# Altium schematic sheet cpu.SchDoc(cpu)
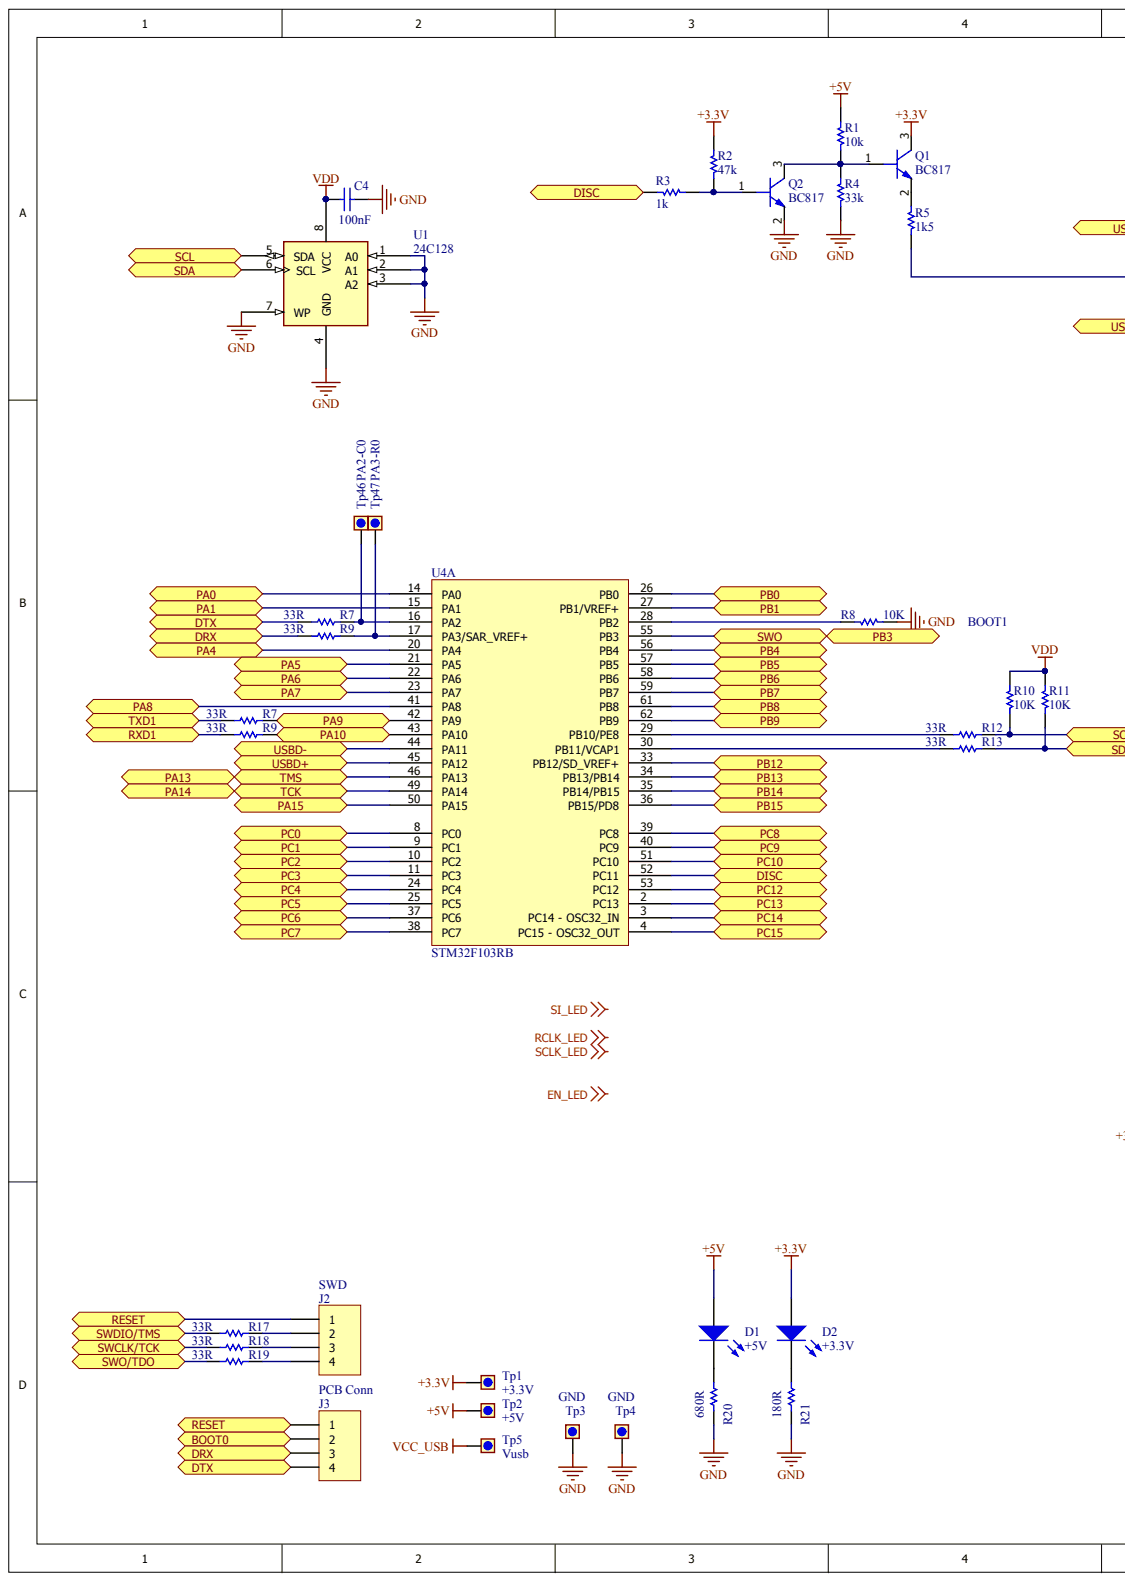
[diagram p0: cpu.SchDoc, left]
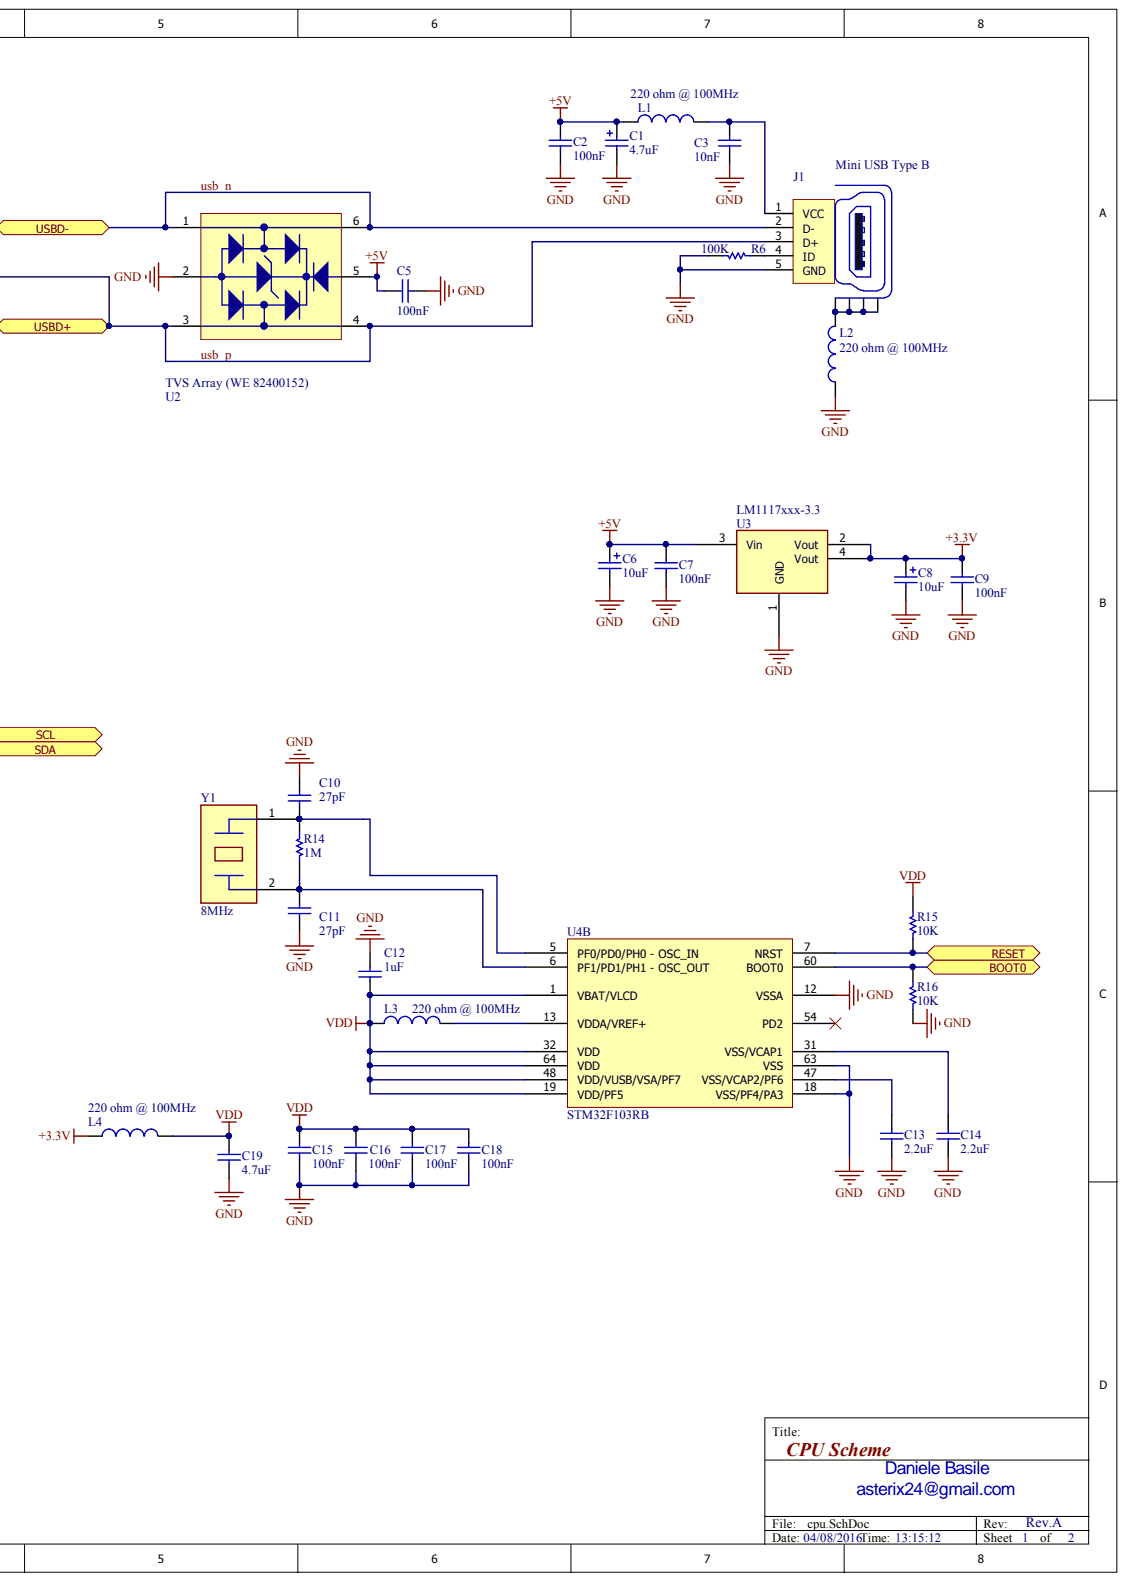
[diagram p1: cpu.SchDoc, right]

## Parts
C1  4.7uF  [1206_[3216]]
C2  100nF  [0603_[1608]]
C3  10nF  [0603_[1608]]
C4  100nF  [0603_[1608]]
C5  100nF  [0603_[1608]]
C6  10uF  [1206_[3216]]
C7  100nF  [0603_[1608]]
C8  10uF  [1206_[3216]]
C9  100nF  [0603_[1608]]
C10  27pF  [0603_[1608]]
C11  27pF  [0603_[1608]]
C12  1uF  [0603_[1608]]
C13  2.2uF  [0603_[1608]]
C14  2.2uF  [0603_[1608]]
C15  100nF  [0603_[1608]]
C16  100nF  [0603_[1608]]
C17  100nF  [0603_[1608]]
C18  100nF  [0603_[1608]]
C19  4.7uF  [0603_[1608]]
D1  +5V  [0603_[1608]_LED]
D2  +3.3V  [0603_[1608]_LED]
J1  Mini USB Type B  [usb_typeB_mini_smd]
J2  SWD  [HEADER_1X4_2.54MM_THD]
J3  PCB Conn  [HEADER_1X4_2.54MM_THD]
L1  220 ohm @ 100MHz  [0805_[2012]]
L2  220 ohm @ 100MHz  [0805_[2012]]
L3  220 ohm @ 100MHz  [0805_[2012]]
L4  220 ohm @ 100MHz  [0805_[2012]]
Q1  BC817  [SOT-23]
Q2  BC817  [SOT-23]
R1  10k  [0603_[1608]]
R2  47k  [0603_[1608]]
R3  1k  [0603_[1608]]
R4  33k  [0603_[1608]]
R5  1k5  [0603_[1608]]
R6  100K  [0603_[1608]]
R7  33R  [0603_[1608]]
R7  33R  [0603_[1608]]
R8  10K  [0603_[1608]]
R9  33R  [0603_[1608]]
R9  33R  [0603_[1608]]
R10  10K  [0603_[1608]]
R11  10K  [0603_[1608]]
R12  33R  [0603_[1608]]
R13  33R  [0603_[1608]]
R14  1M  [0603_[1608]]
R15  10K  [0603_[1608]]
R16  10K  [0603_[1608]]
R17  33R  [0603_[1608]]
R18  33R  [0603_[1608]]
R19  33R  [0603_[1608]]
R20  680R  [0603_[1608]]
R21  180R  [0603_[1608]]
Tp1  +3.3V  [TEST_POINT_THD_D1.8MM]  (no BOM)
Tp2  +5V  [TEST_POINT_THD_D1.8MM]  (no BOM)
Tp3  GND  [TEST_POINT_THD_D1.8MM]  (no BOM)
Tp4  GND  [TEST_POINT_THD_D1.8MM]  (no BOM)
Tp5  Vusb  [TEST_POINT_THD_D1.8MM]  (no BOM)
Tp46  PA2-C0  [TEST_POINT_THD_D1.8MM]  (no BOM)
Tp47  PA3-R0  [TEST_POINT_THD_D1.8MM]  (no BOM)
U1  24C128  [SOIC8]
U2  TVS Array (WE 82400152)  [SOT-563]
U3  LM1117xxx-3.3  [SOT-223]
U4  STM32F103RB  [TSQFP50P1200X1200X160-64N]  (parts A,B)
Y1  8MHz  [CRYSTAL_HC49UP]

## Ports
BOOT0, DISC, DRX, DTX, PA0, PA1, PA4, PA5, PA6, PA7, PA8, PA9, PA10, PA13, PA14, PA15, PB0, PB1, PB3, PB4, PB5, PB6, PB7, PB8, PB9, PB12, PB13, PB14, PB15, PC0, PC1, PC2, PC3, PC4, PC5, PC6, PC7, PC8, PC9, PC10, PC12, PC13, PC14, PC15, RESET, RXD1, SCL, SDA, SWCLK/TCK, SWDIO/TMS, SWO, SWO/TDO, TCK, TMS, TXD1, USBD+, USBD-

## Nets
+3.3V: C8.1 C9.2 D2.1 L4.1 Q1.3 R2.1 Tp1.1 U3.2(Vout) U3.4(Vout)
+5V: C1.1 C2.1 C5.2 C6.1 C7.2 D1.1 L1.1 R1.1 Tp2.1 U2.5 U3.3(Vin)
GND: C1.2 C2.2 C3.2 C4.1 C5.1 C6.2 C7.1 C8.2 C9.1 C10.2 C11.2 C12.2 C13.1 C14.1 C15.2 C16.2 C17.2 C18.2 C19.1 J1.5(GND) L2.1 Q2.2 R4.2 R6.2 R8.2 R16.1 R20.1 R21.1 Tp3.1 Tp4.1 U1.1(A0) U1.2(A1) U1.3(A2) U1.4(GND) U1.7(WP) U2.2 U3.1(GND) U4.12(VSSA) U4.18(VSS/PF4/PA3) U4.63(VSS)
VCC_USB: Tp5.1
VDD: C4.2 C12.1 C15.1 C16.1 C17.1 C18.1 C19.2 L3.1 L4.2 R10.2 R11.2 R15.1 U1.8(VCC) U4.1(VBAT/VLCD) U4.19(VDD/PF5) U4.32(VDD) U4.48(VDD/VUSB/VSA/PF7) U4.64(VDD)
BOOT0: J3.2 R16.2 U4.60(BOOT0) ; port BOOT0
DISC: R3.2 U4.52(PC11) ; port DISC
DRX: J3.3 R9.2 ; port DRX
DTX: J3.4 R7.2 ; port DTX
PA0: U4.14(PA0) ; port PA0
PA1: U4.15(PA1) ; port PA1
PA4: U4.20(PA4) ; port PA4
PA5: U4.21(PA5) ; port PA5
PA6: U4.22(PA6) ; port PA6
PA7: U4.23(PA7) ; port PA7
PA8: U4.41(PA8) ; port PA8
PA9: R7.1 U4.42(PA9) ; port PA9
PA10: R9.1 U4.43(PA10) ; port PA10
PA13: U4.46(PA13) ; port PA13, port TMS
PA14: U4.49(PA14) ; port PA14, port TCK
PA15: U4.50(PA15) ; port PA15
PB0: U4.26(PB0) ; port PB0
PB1: U4.27(PB1/VREF+) ; port PB1
PB3: U4.55(PB3) ; port PB3, port SWO
PB4: U4.56(PB4) ; port PB4
PB5: U4.57(PB5) ; port PB5
PB6: U4.58(PB6) ; port PB6
PB7: U4.59(PB7) ; port PB7
PB8: U4.61(PB8) ; port PB8
PB9: U4.62(PB9) ; port PB9
PB12: U4.33(PB12/SD_VREF+) ; port PB12
PB13: U4.34(PB13/PB14) ; port PB13
PB14: U4.35(PB14/PB15) ; port PB14
PB15: U4.36(PB15/PD8) ; port PB15
PC0: U4.8(PC0) ; port PC0
PC1: U4.9(PC1) ; port PC1
PC2: U4.10(PC2) ; port PC2
PC3: U4.11(PC3) ; port PC3
PC4: U4.24(PC4) ; port PC4
PC5: U4.25(PC5) ; port PC5
PC6: U4.37(PC6) ; port PC6
PC7: U4.38(PC7) ; port PC7
PC8: U4.39(PC8) ; port PC8
PC9: U4.40(PC9) ; port PC9
PC10: U4.51(PC10) ; port PC10
PC12: U4.53(PC12) ; port PC12
PC13: U4.2(PC13) ; port PC13
PC14: U4.3(PC14_-_OSC32_IN) ; port PC14
PC15: U4.4(PC15_-_OSC32_OUT) ; port PC15
RESET: J2.1 J3.1 R15.2 U4.7(NRST) ; port RESET
RXD1: R9.2 ; port RXD1
SCL: R10.1 R12.1 U1.5(SDA) ; port SCL
SDA: R11.1 R13.1 U1.6(SCL) ; port SDA
SWCLK/TCK: R18.2 ; port SWCLK/TCK
SWDIO/TMS: R17.2 ; port SWDIO/TMS
SWO/TDO: R19.2 ; port SWO/TDO
TXD1: R7.2 ; port TXD1
USB_N: J1.2(D-) U2.1 U2.6 U4.44(PA11)
USB_P: J1.3(D+) R5.2 U2.3 U2.4 U4.45(PA12)
NetC3_1: C3.1 J1.1(VCC) L1.2
NetC10_1: C10.1 R14.2 U4.5(PF0/PD0/PH0_-_OSC_IN) Y1.1
NetC11_1: C11.1 R14.1 U4.6 Y1.2
NetC13_2: C13.2 U4.47(VSS/VCAP2/PF6)
NetC14_2: C14.2 U4.31(VSS/VCAP1)
NetD1_2: D1.2 R20.2
NetD2_2: D2.2 R21.2
NetJ1_4: J1.4(ID) R6.1
NetJ1_Z1: J1.Z1 J1.Z2 J1.Z3 J1.Z4 L2.2
NetJ2_2: J2.2 R17.1
NetJ2_3: J2.3 R18.1
NetJ2_4: J2.4 R19.1
NetL3_2: L3.2 U4.13(VDDA/VREF+)
NetQ1_1: Q1.1 Q2.3 R1.2 R4.1
NetQ1_2: Q1.2 R5.1
NetQ2_1: Q2.1 R2.2 R3.1
NetR7_1: R7.1 Tp46.1 U4.16(PA2)
NetR8_1: R8.1 U4.28(PB2)
NetR9_1: R9.1 Tp47.1 U4.17(PA3/SAR_VREF+)
NetR12_2: R12.2 U4.29(PB10/PE8)
NetR13_2: R13.2 U4.30(PB11/VCAP1)
EN_LED:
RCLK_LED:
SCLK_LED:
SI_LED:

Not connected: U4 54(PD2)

## Library data of the parts
C1 = Cap Pol1: Ceramic X7R 16V; Value: 10uF; Component Kind: Standard; Published: 8-Jun-2000; Revision: July-2002: Re-released for DXP Platform.; Signal Integrity: Cap Pol; Simulation: CAP; Library Name: DEVELER_Library.SchLib; Publisher: Altium Limited
C2 C4 C15-C18 = Cap: Ceramic X7R 50V; Value: 10nF; Component Kind: Standard; Published: 8-Jun-2000; Revision: July-2002: Re-released for DXP Platform.; Signal Integrity: Cap; Simulation: CAP; Publisher: Altium Limited
  C15-C18: Library Name: Miscellaneous Devices.IntLib
  C2 C4: Library Name: DEVELER_Library.SchLib
C3 = Cap: Ceramic X7R 50V; Component Kind: Standard; Published: 8-Jun-2000; Revision: July-2002: Re-released for DXP Platform.; Signal Integrity: Cap; Simulation: CAP; Value: 10nF; Library Name: DEVELER_Library.SchLib; Publisher: Altium Limited
C5 C7 C9 = Cap: Ceramic X7R 50V; Value: 100pF; Component Kind: Standard; LatestRevisionDate: 17-Jul-2002; LatestRevisionNote: Re-released for DXP Platform.; PackageDescription: Radial Cap, Thru-Hole; 2 Leads; 0.3 in Pin Spacing; PackageReference: RAD-0.3; Published: 8-Jun-2000; Signal Integrity: Cap; Simulation: CAP; Publisher: Altium Limited
  C5: Library Name: Miscellaneous Devices.IntLib
  C7 C9: Library Name: DEVELER_Library.SchLib
C6 C8 = Cap Pol1: Ceramic X5R 25V; Component Kind: Standard; Published: 8-Jun-2000; Revision: July-2002: Re-released for DXP Platform.; Signal Integrity: Cap Pol; Simulation: CAP; Value: 10uF; Library Name: DEVELER_Library.SchLib; Publisher: Altium Limited
C10 C11 = Cap: Ceramic NP0 +/- 0.1; Value: 10nF; Component Kind: Standard; Published: 8-Jun-2000; Revision: July-2002: Re-released for DXP Platform.; Signal Integrity: Cap; Simulation: CAP; Library Name: simboli.SchLib; Publisher: Altium Limited
C12 C19 = Cap: Ceramic X7R 16V; Value: 10nF; Component Kind: Standard; Published: 8-Jun-2000; Revision: July-2002: Re-released for DXP Platform.; Signal Integrity: Cap; Simulation: CAP; Publisher: Altium Limited
  C12: Library Name: Miscellaneous Devices.IntLib
  C19: Library Name: simboli.SchLib
C13 C14 = Cap: Ceramic 6.3V X7R; Value: 10nF; Component Kind: Standard; Library Name: Miscellaneous Devices.IntLib; Published: 8-Jun-2000; Revision: July-2002: Re-released for DXP Platform.; Signal Integrity: Cap; Simulation: CAP; Publisher: Altium Limited
D1 D2 = LED0: LED Green; Component Kind: Standard; LatestRevisionDate: 08-Jul-2005; LatestRevisionNote: Mech Layer 1 removed.; PackageDescription: LED; 2 Leads; PackageReference: LED-0; Published: 8-Jun-2000; Simulation: LED0; Library Name: DEVELER_Library.SchLib; Publisher: Altium Limited
J1 = USB_Con_Type_B_mini_SMT: Mini USB SMT Type B 5 Contacts; Manufacturer: Würth Elektronik eiSos GmbH; partNo: 65100516121; ComponentLink1 Description: Manufacturer; ComponentLink2 Description: Datasheet; NoPins: 5; Component Kind: Standard; ComponentLink1URL: http://www.we-online.de; ComponentLink2URL: http://katalog.we-online.de/em/datasheet/65100516121.pdf; Created Date: 10/10/2014; Created by: Karrer Zheng; Library Name: develer_library.schlib
J2 J3 = Header 4: Pin Header, 1x4, 2.54mm.; Component Kind: Standard; LatestRevisionDate: 17-Jul-2002; LatestRevisionNote: Re-released for DXP Platform.; Library Name: Miscellaneous Connectors.IntLib; Signal Integrity: Connector; Publisher: Altium Limited
L1 L2 L4 = Inductor: WE 742792022; Component Kind: Standard; Library Name: DEVELER_Library.SchLib
L3 = Inductor: Value: 100uH; Component Kind: Standard; Description: Inductor; Library Name: Miscellaneous Devices.IntLib; Published: 8-Jun-2000; Revision: July-2002: Re-released for DXP Platform.; Publisher: Altium Limited
Q1 Q2 = BC817: BJT NPN; Component Kind: Standard; Library Name: develer_library.schlib
R1 R2 R4 R5 R7 R7-R9 R9-R19 = Res1: Resistor; Value: 1K; Component Kind: Standard; Published: 8-Jun-2000; Revision: July-2002: Re-released for DXP Platform.; Signal Integrity: Res; Simulation: RESISTOR; Publisher: Altium Limited
  R1 R2 R4 R5 R10 R11 R14: Library Name: DEVELER_Library.SchLib
  R7 R7-R9 R9 R12 R13 R15-R19: Library Name: simboli.SchLib
R3 R20 R21 = Res1: Resistor; Component Kind: Standard; Revision: July-2002: Re-released for DXP Platform.; Signal Integrity: Res; Simulation: RESISTOR; Library Name: DEVELER_Library.SchLib
  R3: Published: 8-Jun-2000; Value: 1K; Publisher: Altium Limited
R6 = Resistor_ST: Resistor; Value: 10K; Component Kind: Standard; Library Name: Miscellaneous Devices.IntLib
Tp1-Tp5 Tp46 Tp47 = Test_point: Test point; Library Name: DEVELER_Library.SchLib
U1 = EEPROM-SOIC8: EEPROM; Component Kind: Standard; Library Name: DEVELER_Library.SchLib
U2 = TVS_Diode_Array: Component Kind: Standard; Description: TVS Array (WE 82400152); Library Name: DEVELER_Library.SchLib
U3 = LM1117-FIXED-OUT: LM1117 LDO Fixed output; Component Kind: Standard; Library Name: develer_library.schlib
Y1 = CRYSTAL-2p: Component Kind: Standard; Library Name: develer_library.schlib
U4 = STM32F103RB: Component Kind: Standard; Description: STM32F103RB; Library Name: develer_library.schlib
